# Altium schematic sheet BridgedT & Decay.SchDoc("BridgedT & Decay")
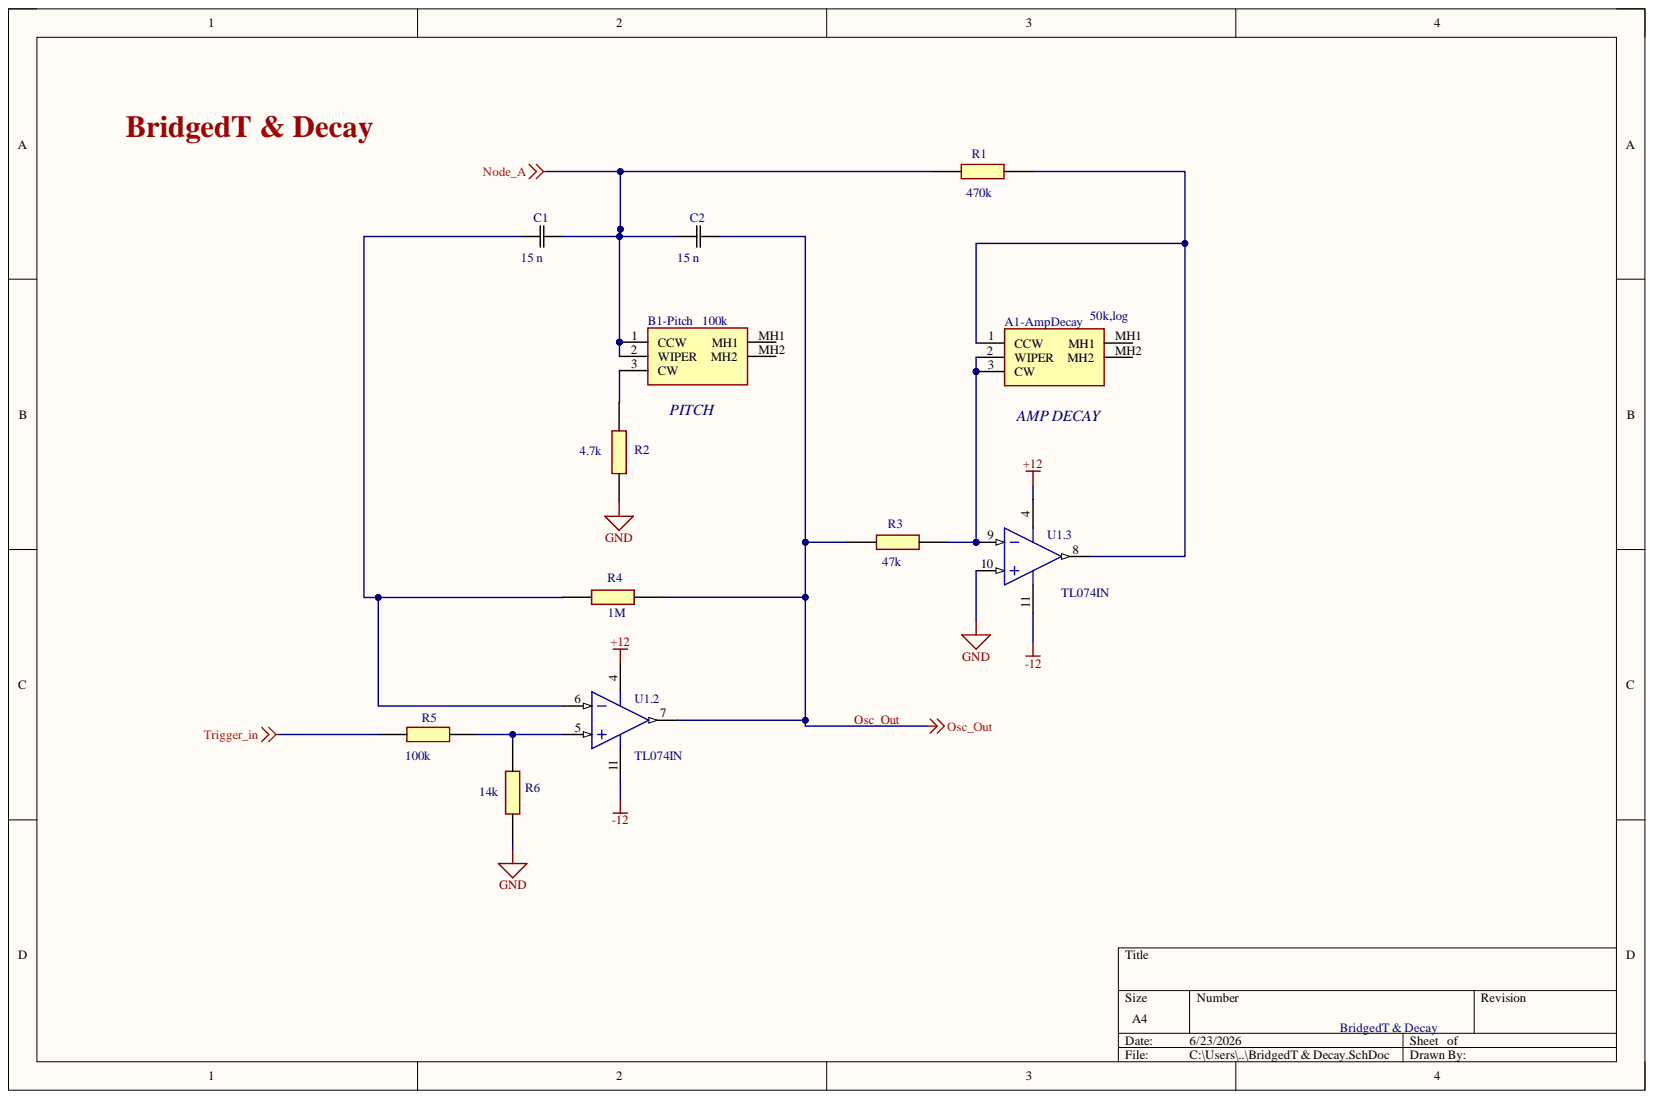
[diagram p0: BridgedT & Decay.SchDoc]

## Parts
A1-AmpDecay  value 50k,log  [PTV09A4025FB104-6]  (hidden comment: PTV09A-4025F-A503)
B1-Pitch  value 100k  [PTV09A4025FB104-6]  (hidden comment: PTV09A-4025F-B104)
C1  value 15 n  [CAP_MMK5_102J50_KEM]  (hidden comment: MMK5153K50J01L4BULK)
C2  value 15 n  [CAP_MMK5_102J50_KEM]  (hidden comment: MMK5153K50J01L4BULK)
R1  value 470k  [RESAD1590W60L630D240]  (hidden comment: MFR-25FTF52-600K)
R2  value 4.7k  [RESAD1590W60L630D240]  (hidden comment: MFR-25FTF52-600K)
R3  value 47k  [RESAD1590W60L630D240]  (hidden comment: MFR-25FTF52-600K)
R4  value 1M  [RESAD1590W60L630D240]  (hidden comment: MFR-25FTF52-600K)
R5  value 100k  [RESAD1590W60L630D240]  (hidden comment: MFR-25FTF52-600K)
R6  value 14k  [RESAD1590W60L630D240]  (hidden comment: MFR-25FTF52-600K)
U1  TL074IN  [N0014A]  (parts .2,.3)

## Nets
GND: R2.2 R6.2 U1.10
Node_A: B1-Pitch.1(CCW) B1-Pitch.2(WIPER) C1.2 C2.1 R1.1
Trigger_in: R5.2
Osc_Out: C2.2 R3.2 R4.2 U1.7
NetA1-AmpDecay_1: A1-AmpDecay.1(CCW) R1.2 U1.8
NetA1-AmpDecay_2: A1-AmpDecay.2(WIPER) A1-AmpDecay.3(CW) R3.1 U1.9
NetB1-Pitch_3: B1-Pitch.3(CW) R2.1
NetC1_1: C1.1 R4.1 U1.6
NetR5_1: R5.1 R6.1 U1.5
+12:
-12:

Not connected: A1-AmpDecay MH1 MH2; B1-Pitch MH1 MH2; U1 4 11

## Library data of the parts
A1-AmpDecay = CMP-009-00185-1: Variable Resistor; Manufacturer_Name: Bourns; Manufacturer_Part_Number: PTV09A-4025F-B104; Arrow Part Number: PTV09A-4025F-B104; Arrow Price/Stock: https://www.arrow.com/en/products/ptv09a-4025f-b104/bourns?region=nac; Component Kind: Standard; Component Type: Standard; Datasheet Link: https://www.digikey.ph/en/products/detail/bourns-inc/PTV09A-4025F-B104/3820577?msockid=39f1ec84d77b6f4428b7f904d6676eed; Design Item ID: PTV09A-4025F-B104; Height: 25.5mm; Mouser Part Number: 652-PTV09A4025FB104; Mouser Price/Stock: https://www.mouser.co.uk/ProductDetail/Bourns/PTV09A-4025F-B104?qs=fgHA1UgJI8DoC1GZkaXClg%3D%3D; Pins: 5; Source: PTV0910k.SchLib; Library Name: EMEA - Politecnico di Torino - PoliTek Music
B1-Pitch = CMP-009-00185-1: Variable Resistor; Manufacturer_Name: Bourns; Arrow Part Number: PTV09A-4025F-B104; Arrow Price/Stock: https://www.arrow.com/en/products/ptv09a-4025f-b104/bourns?region=nac; Component Kind: Standard; Component Type: Standard; Datasheet Link: https://www.digikey.ph/en/products/detail/bourns-inc/PTV09A-4025F-B104/3820577?msockid=39f1ec84d77b6f4428b7f904d6676eed; Design Item ID: PTV09A-4025F-B104; Height: 25.5mm; Manufacturer_Part_Number: PTV09A-4025F-B104; Mouser Part Number: 652-PTV09A4025FB104; Mouser Price/Stock: https://www.mouser.co.uk/ProductDetail/Bourns/PTV09A-4025F-B104?qs=fgHA1UgJI8DoC1GZkaXClg%3D%3D; Pins: 5; Source: PTV0910k.SchLib; Library Name: EMEA - Politecnico di Torino - PoliTek Music
C1 C2 = CMP-032-000009-2: Condensatori a film 50volts 0.015uF 10% LS 5mm; Mfr_Name: Kemet; Component Kind: Standard; Datasheet Link: https://www.mouser.it/datasheet/2/447/F3294_MMK-3316041.pdf; Design Item ID: MMK5103K50J01L4BULK; Source: MMK5103K50J01L4BULK.SchLib; Library Name: PoliTeK
R1-R6 = CMP-009-00182-2: Metal Film Resistors - Through Hole; Manufacturer_Name: YAGEO; Component Kind: Standard; Component Type: Standard; Datasheet Link: https://www.mouser.sg/datasheet/2/447/YAGEO_MFR_datasheet_2023v3-3324391.pdf; Design Item ID: MFR-25FTF52-600K; Manufacturer_Part_Number: MFR-25FTF52-600K; Mouser Part Number: 603-MFR-25FTF52-600K; Mouser Price/Stock: https://www.mouser.co.uk/ProductDetail/YAGEO/MFR-25FTF52-600K?qs=xZ%2FP%252Ba9zWqYtTZdHR75SUg%3D%3D; Pins: 2; Source: SamacSys.SchLib; Library Name: EMEA - Politecnico di Torino - PoliTek Music
U1 = CMP-0017-00871-2: Quad Low-Noise JFET-Input General-Purpose Operational Amplifier, 7 to 36 V, -40 to 85 degC, 14-pin DIP (N14), Pb-Free (RoHS); Manufacturer: Texas Instruments; CMRR(Min)(dB): 70; GBW(Typ)(MHz): 3; IIB(Max)(pA): 200; Iq per channel(Max)(mA): 2.5; Number of Channels: 4; Offset Drift(Typ)(uV/C): 18; Rating: Military; Slew Rate(Typ)(V/us): 13; Sub Family: General Purpose Amplifier, High Supply Voltage Amplifier (>=30V); Total Supply Voltage(Max)(+5V is 5, +/-5V is 10): 36; Total Supply Voltage(Min)(+5V is 5, +/-5V is 10): 7; Vio (25C)(Max)(mV): 10; Code_JEDEC: MS-001; Component Kind: Standard; ComponentLink1Description: Manufacturer URL; ComponentLink1URL: http://www.ti.com/; ComponentLink2Description: Datasheet; ComponentLink2URL: http://www.ti.com/lit/ds/symlink/tl074.pdf; ComponentLink3Description: Package Specification; ComponentLink3URL: http://www.ti.com/lit/ml/mpdi002c/mpdi002c.pdf; DatasheetVersion: SLOS080J; Eco-Plan: Pb-Free (RoHS); Library Name: Altium Content Vault; Mounting Technology: Through Hole; PackageDescription: 14-Pin Plastic In-Line, Body 19.305 x 6.35 mm, Pitch 2.54 mm; PackageReference: N0014A; PackageVersion: 4040049/E, 12/2002; PartNumber: TL074IN; Vn at 1kHz(Typ)(nV/rtHz): 18
